# Altium schematic sheet TP_STM32_SPI.SchDoc
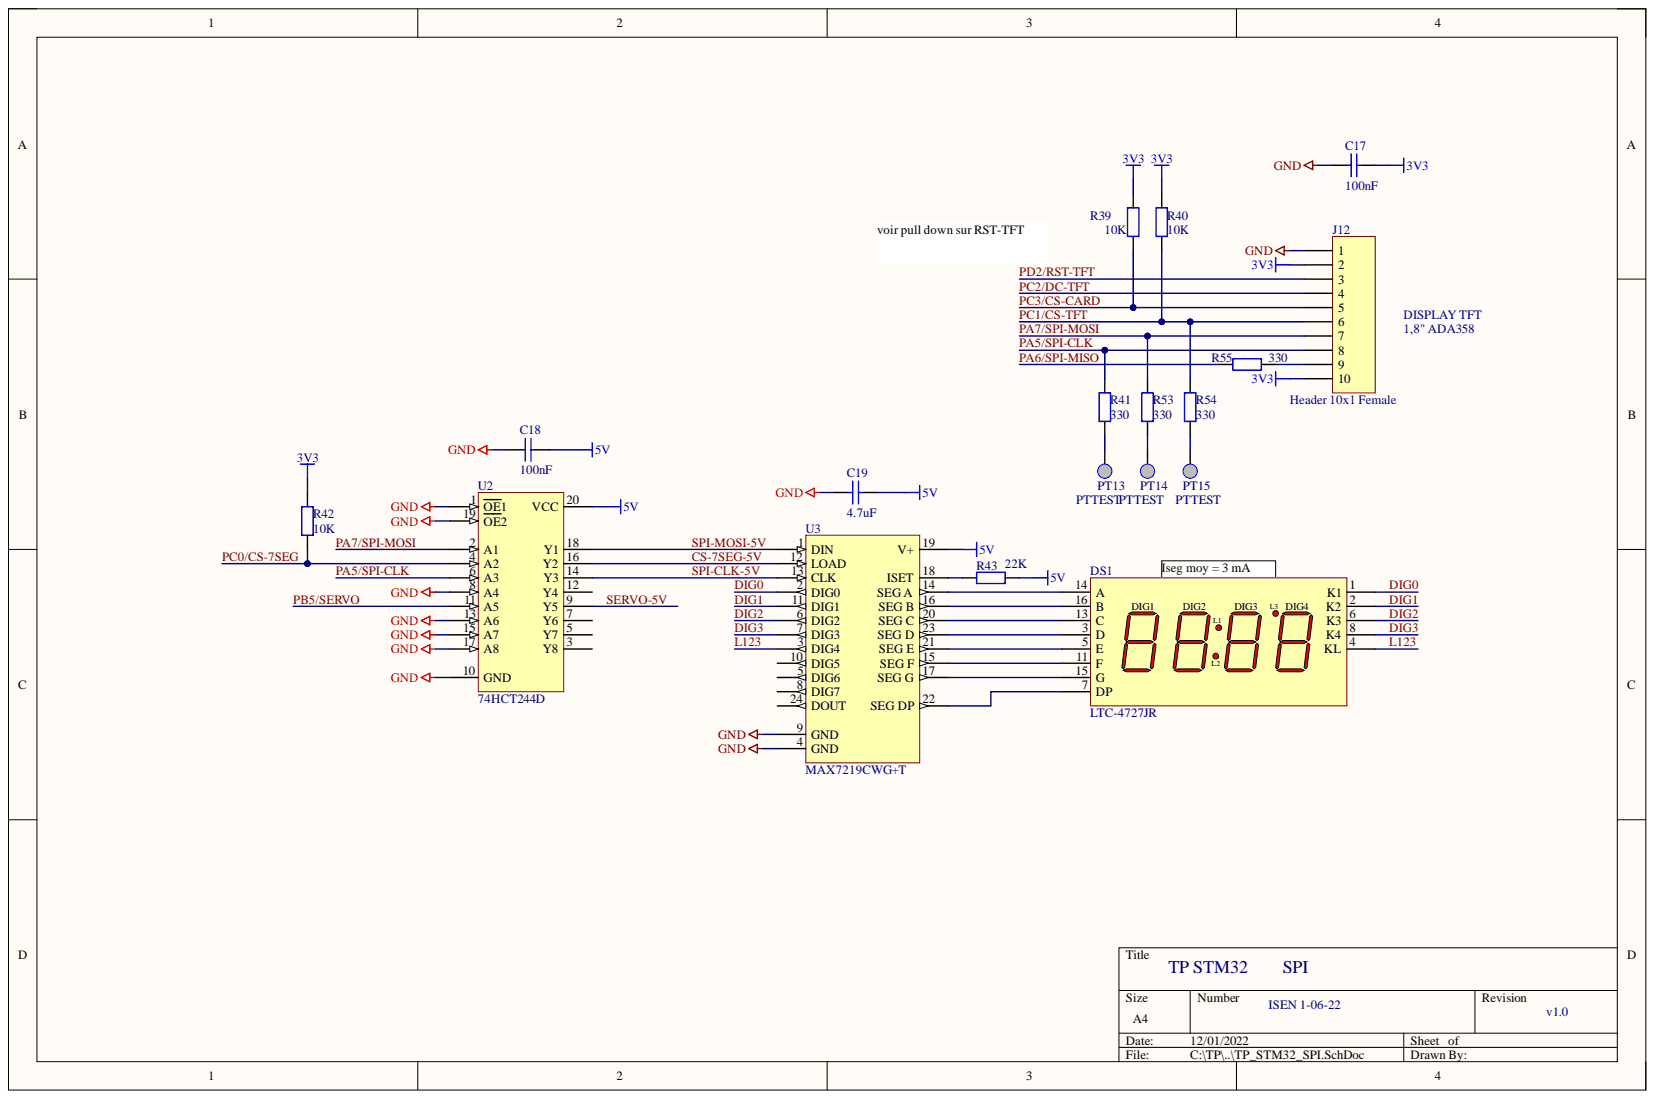
[diagram p0: TP_STM32_SPI.SchDoc]

## Parts
C17  100nF  [CC2012-0805]
C18  100nF  [CC2012-0805]
C19  4.7uF  [CC2012-0805]
DS1  LTC-4727JR  [LTC-4727JR]
J12  Header 10x1 Female  [TFT_ADAFRUIT_1X10F]
PT13  PTTEST  [PTEST]
PT14  PTTEST  [PTEST]
PT15  PTTEST  [PTEST]
R39  10K  [R2012-0805]
R40  10K  [R2012-0805]
R41  330  [R2012-0805]
R42  10K  [R2012-0805]
R43  22K  [R2012-0805]
R53  330  [R2012-0805]
R54  330  [R2012-0805]
R55  330  [R2012-0805]
U2  74HCT244D  [DW020_N]
U3  MAX7219CWG+T  [WSO24_N]

## Nets
3V3: C17.1 J12.2 J12.10 R39.1 R40.1 R42.1
5V: C18.2 C19.2 R43.2 U2.20(VCC) U3.19(V+)
GND: C17.2 C18.1 C19.1 J12.1 U2.1(OE1) U2.8(A4) U2.10(GND) U2.13(A6) U2.15(A7) U2.17(A8) U2.19(OE2) U3.4(GND) U3.9(GND)
CS-7SEG-5V: U2.16(Y2) U3.12(LOAD)
DIG0: DS1.1(K1) U3.2(DIG0)
DIG1: DS1.2(K2) U3.11(DIG1)
DIG2: DS1.6(K3) U3.6(DIG2)
DIG3: DS1.8(K4) U3.7(DIG3)
L123: DS1.4(KL) U3.3(DIG4)
PA5/SPI-CLK: J12.8 R41.2 U2.6(A3)
PA6/SPI-MISO: R55.1
PA7/SPI-MOSI: J12.7 R53.2 U2.2(A1)
PB5/SERVO: U2.11(A5)
PC0/CS-7SEG: R42.2 U2.4(A2)
PC1/CS-TFT: J12.6 R40.2 R54.2
PC2/DC-TFT: J12.4
PC3/CS-CARD: J12.5 R39.2
PD2/RST-TFT: J12.3
SERVO-5V: U2.9(Y5)
SPI-CLK-5V: U2.14(Y3) U3.13(CLK)
SPI-MOSI-5V: U2.18(Y1) U3.1(DIN)
NetDS1_3: DS1.3(D) U3.23(SEG_D)
NetDS1_5: DS1.5(E) U3.21(SEG_E)
NetDS1_7: DS1.7(DP) U3.22(SEG_DP)
NetDS1_11: DS1.11(F) U3.15(SEG_F)
NetDS1_13: DS1.13(C) U3.20(SEG_C)
NetDS1_14: DS1.14(A) U3.14(SEG_A)
NetDS1_15: DS1.15(G) U3.17(SEG_G)
NetDS1_16: DS1.16(B) U3.16(SEG_B)
NetJ12_9: J12.9 R55.2
NetPT13_1: PT13.1 R41.1
NetPT14_1: PT14.1 R53.1
NetPT15_1: PT15.1 R54.1
NetR43_1: R43.1 U3.18(ISET)

Not connected: U2 3(Y8) 5(Y7) 7(Y6) 12(Y4); U3 5(DIG6) 8(DIG7) 10(DIG5) 24(DOUT)

## Library data of the parts
C17 C18 = Cap: Capacitor MLCC X7R 50V; Manufacturer: Generic Components; Class I: Passive; Class II: Capacitor; Component Kind: Standard; Library Name: Miscellaneous Devices.IntLib; Published: 8-Jun-2000; Revision: July-2002: Re-released for DXP Platform.; Signal Integrity: Cap; Simulation: CAP; Value: 100nF; Publisher: Altium Limited
C19 = Cap: Capacitor MLCC X5R 10V; Manufacturer: Generic Components; Class I: Passive; Class II: Capacitor; Component Kind: Standard; Library Name: Miscellaneous Devices.IntLib; Published: 8-Jun-2000; Revision: July-2002: Re-released for DXP Platform.; Signal Integrity: Cap; Simulation: CAP; Value: 4.7uF; Publisher: Altium Limited
DS1 = LTC-4727JR: 4-Digit 7-Segment Display: CC, 88:88, 10 mm RED, Gray Surface; Manufacturer: LITE-ON; LuminousIntensity: 320 ucd (Minimum) at 1mA; Component Kind: Standard; LED_Colour: Red; Library Name: TP_STM32.SCHLIB; PackageDescription: LED, 7-Segment Display, 10 mm, Four Digit, 88:88; 16 Leads; Row Space 10,16 mm; Pitch 2.54 mm; PackageVersion: 12-Apr-2022; Published: 12-Apr-2022
J12 = Header 10: Header 10x1 Female 2.54mm; Manufacturer: SAMTEC; Manufacturer Part Number: SSW-110-01-T-S; Component Kind: Standard; LatestRevisionDate: 17-Jul-2002; LatestRevisionNote: Re-released for DXP Platform.; Library Name: Miscellaneous Connectors.IntLib; Signal Integrity: Connector; Publisher: Altium Limited
PT13-PT15 = POINT-TEST: POINT TEST VERO 20-2136; Class I: Passive; Class II: Resistor; Component Kind: Standard; Library Name: STM8S.SCHLIB; Manufacturer: VERO; Published: 15/12/03; Revision: 1.0; Publisher: ISEN BREST
R39-R43 R53-R55 = Res2: Resistor; Manufacturer: Generic Components; Class I: Passive; Class II: Resistor; Component Kind: Standard; Library Name: Miscellaneous Devices.IntLib; Published: 8-Jun-2000; Revision: July-2002: Re-released for DXP Platform.; Signal Integrity: Res2; Simulation: RESISTOR; Publisher: Altium Limited
  R39 R40 R42: Value: 10K
  R41 R53-R55: Value: 330
  R43: Value: 22K
U2 = SN74HCT244DW: Octal Buffer and Line Driver with 3-State Outputs; Manufacturer: NEXPERIA; Code_IPC: SOIC127P1030-20; Code_JEDEC: MS-013; Component Kind: Standard; ComponentLink1Description: Manufacturer Link; ComponentLink1URL: http://www.ti.com/; ComponentLink2Description: Datasheet; ComponentLink2URL: http://www-s.ti.com/sc/ds/sn74hct244.pdf; DatasheetVersion: May-1997; LatestRevisionDate: 13-Apr-2006; LatestRevisionNote: IPC-7351 Footprint Added.; Library Name: TI Logic Buffer Line Driver.IntLib; PackageDescription: 20-Pin Plastic Small Outline Integrated Circuit 1.27 mm Pitch; PackageReference: DW020; PackageVersion: Mar-2000; PCB3D: DW020; Published: 8-Jun-2000; Signal Integrity: SN74HCT244DW; Publisher: Altium Limited
U3 = MAX7219CWG: Serially Interfaced, 8-Digit LED Display Driver; Manufacturer: MAXIM; Component Kind: Standard; ComponentLink1Description: Manufacturer Link; ComponentLink1URL: http://www.maxim-ic.com/; ComponentLink2Description: Datasheet; ComponentLink2URL: http://pdfserv.maxim-ic.com/en/ds/MAX7219-MAX7221.pdf; DatasheetVersion: Jul-1997; LatestRevisionDate: 13-Apr-2006; LatestRevisionNote: IPC-7351 Footprint Added.; Library Name: Maxim Interface Display Driver.IntLib; PackageDescription: 24-Pin Small Outline Integrated Circuit 1.27 mm Pitch; PackageReference: WSO24; PCB3D: WSO24; Published: 16-Jan-2001; Publisher: Altium Limited
